# Altium schematic sheet ZNXC_V1.0.SchDoc
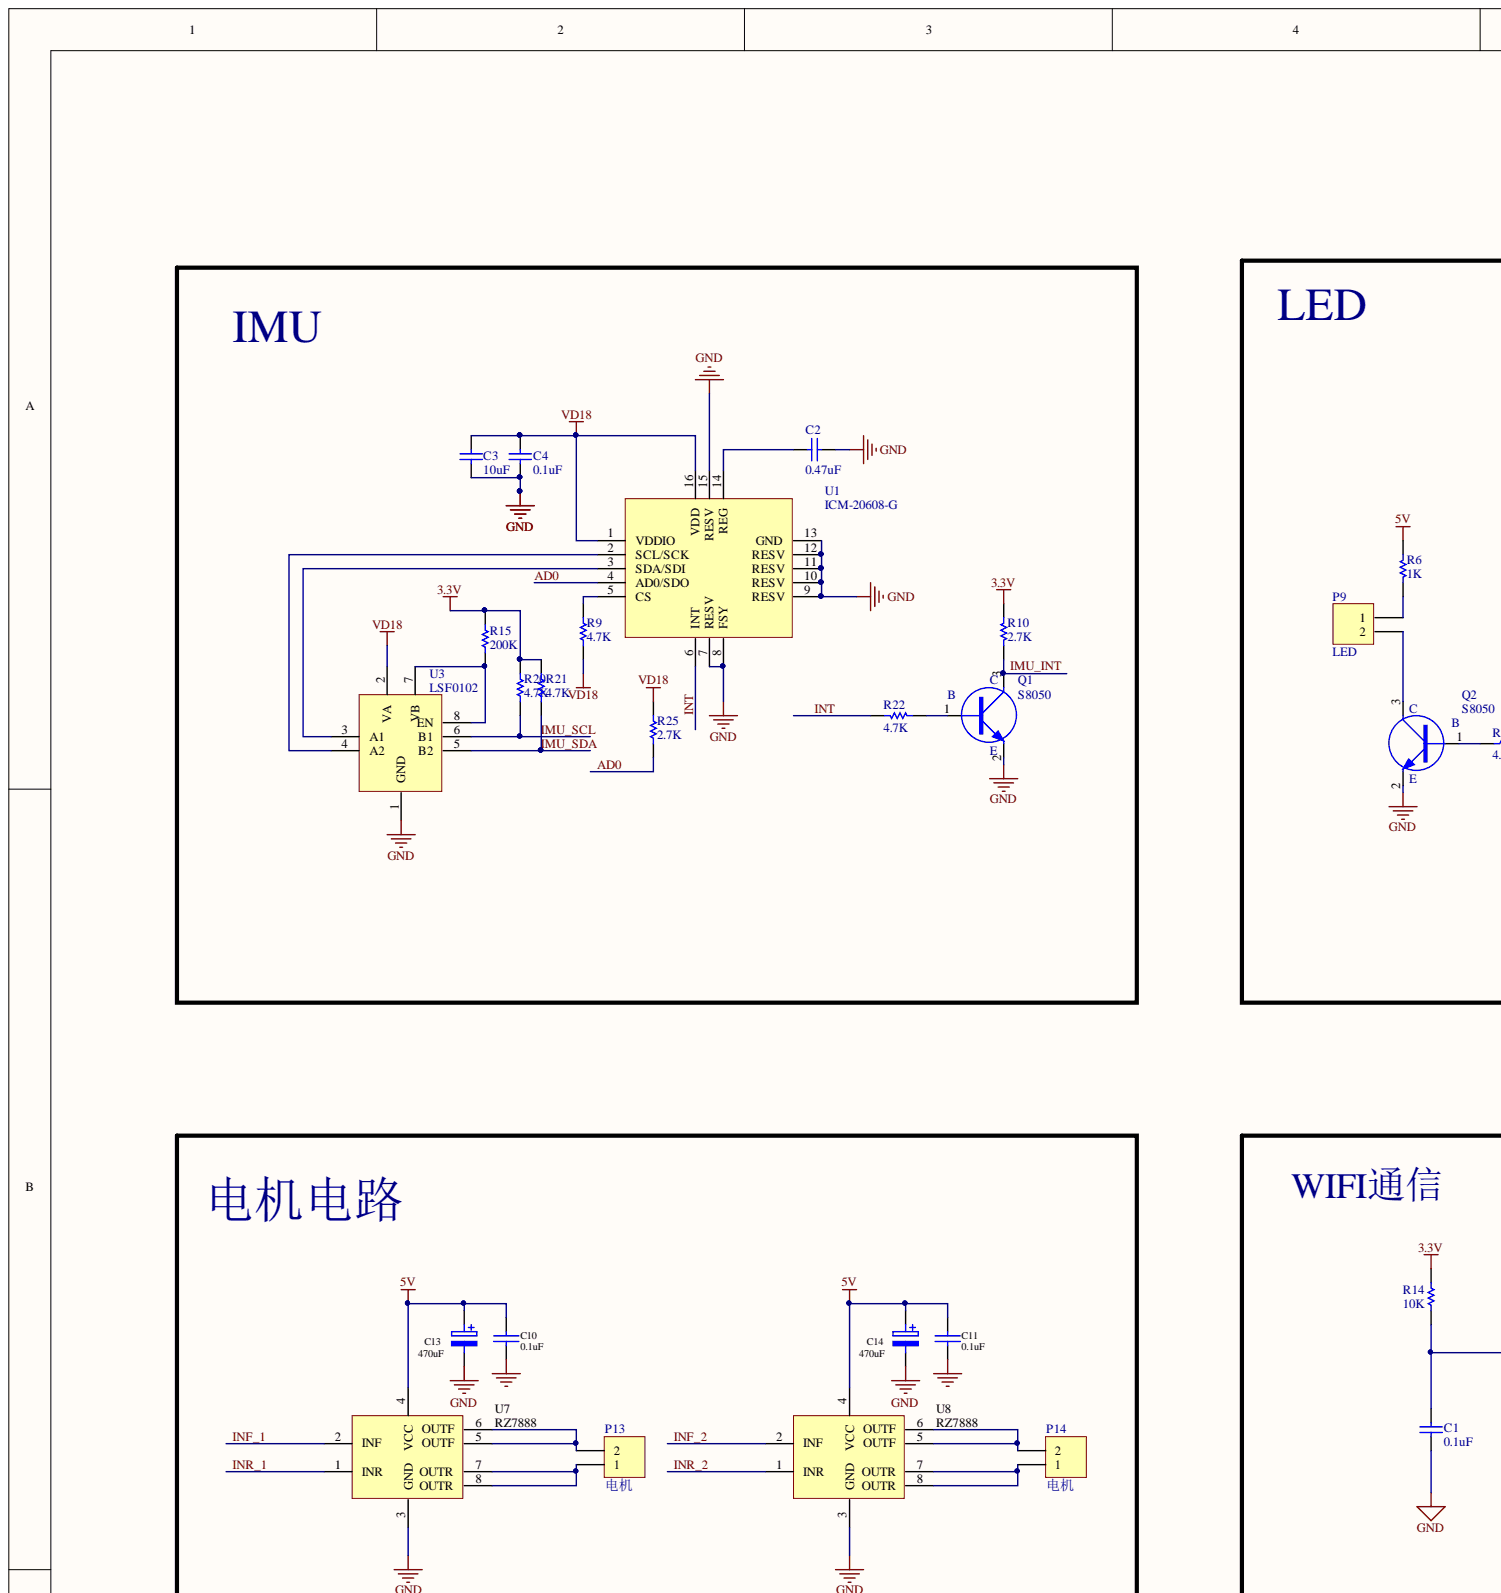
[diagram p0: ZNXC_V1.0.SchDoc, top left]
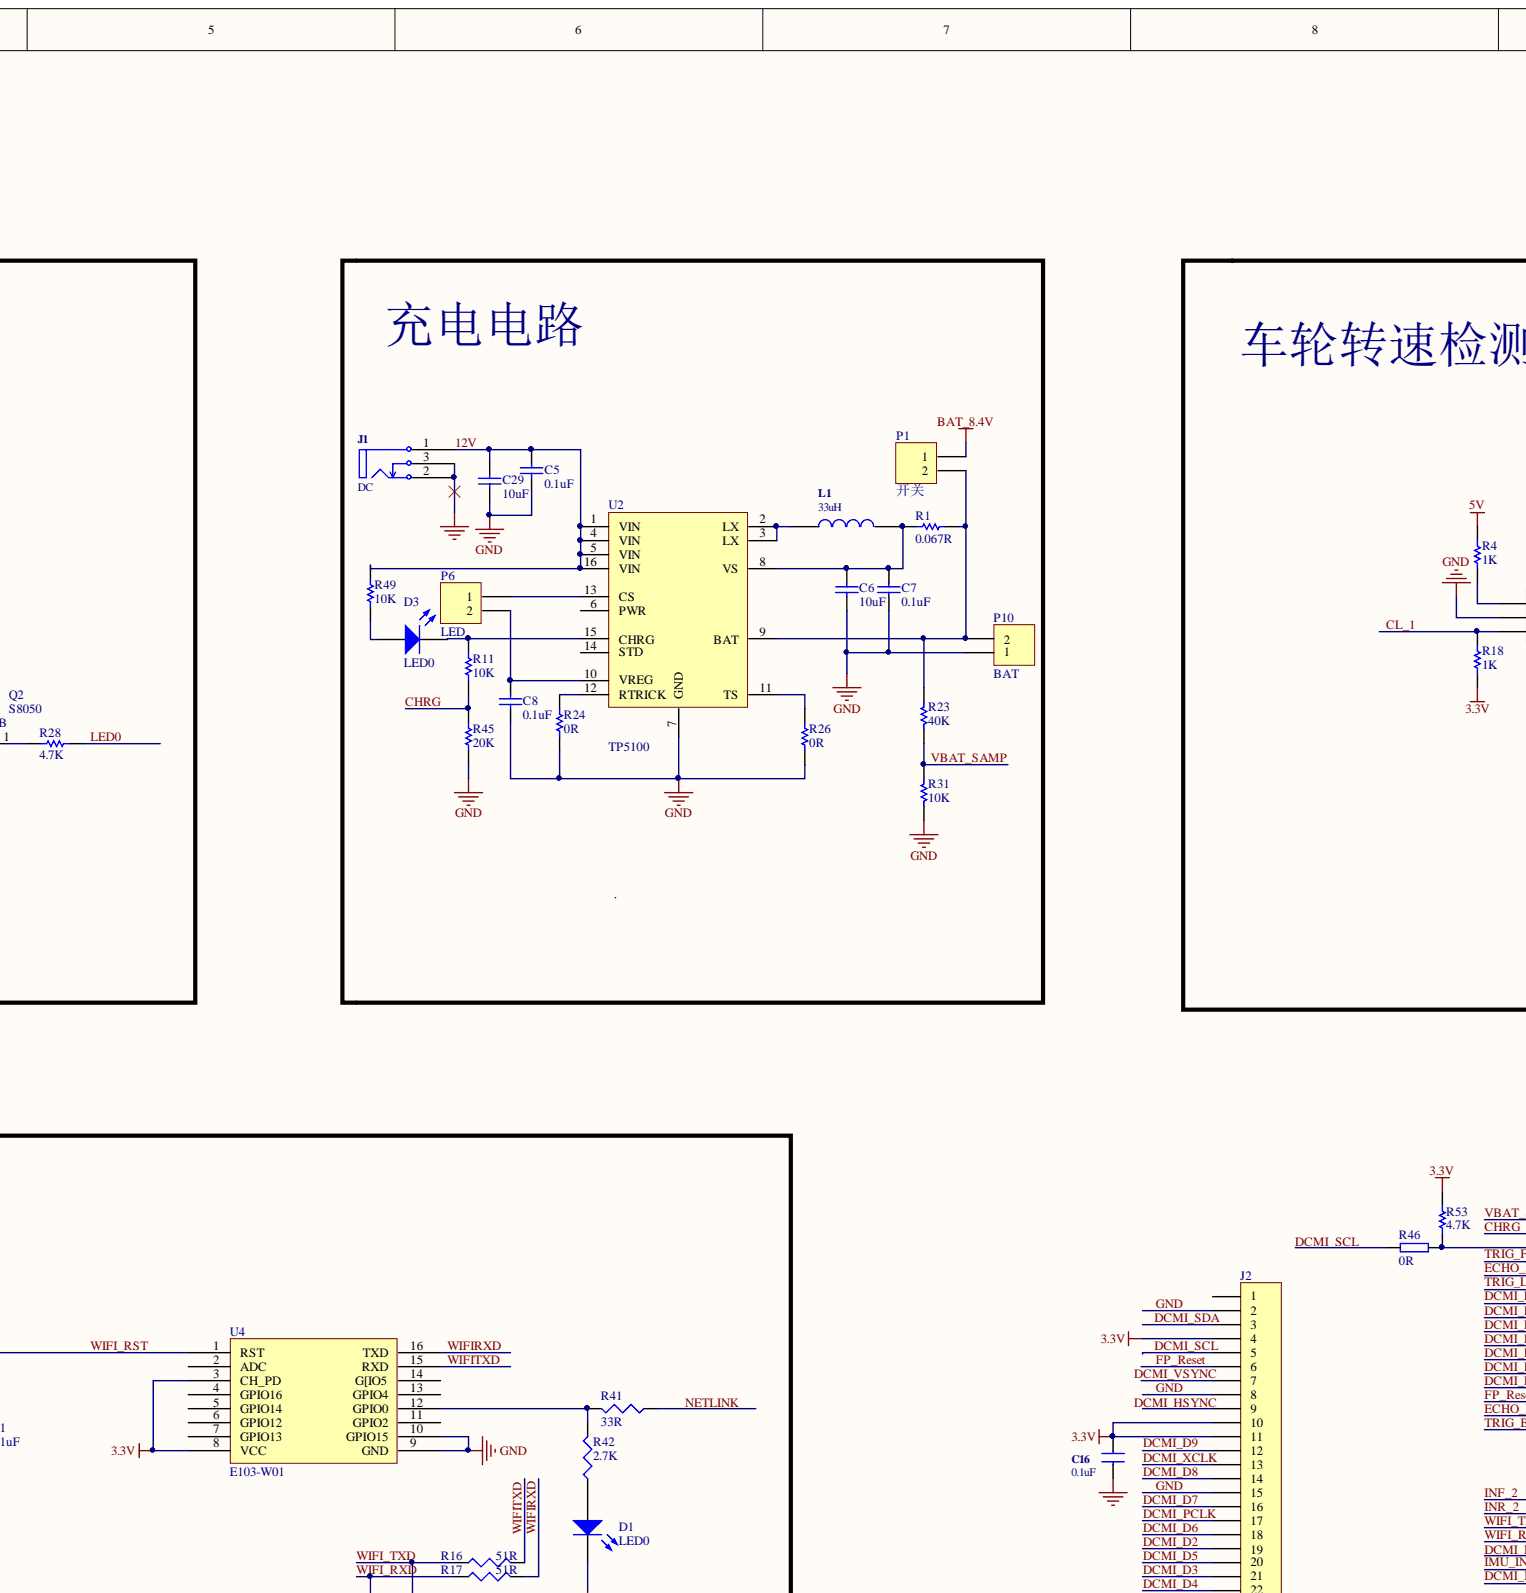
[diagram p1: ZNXC_V1.0.SchDoc, top centre]
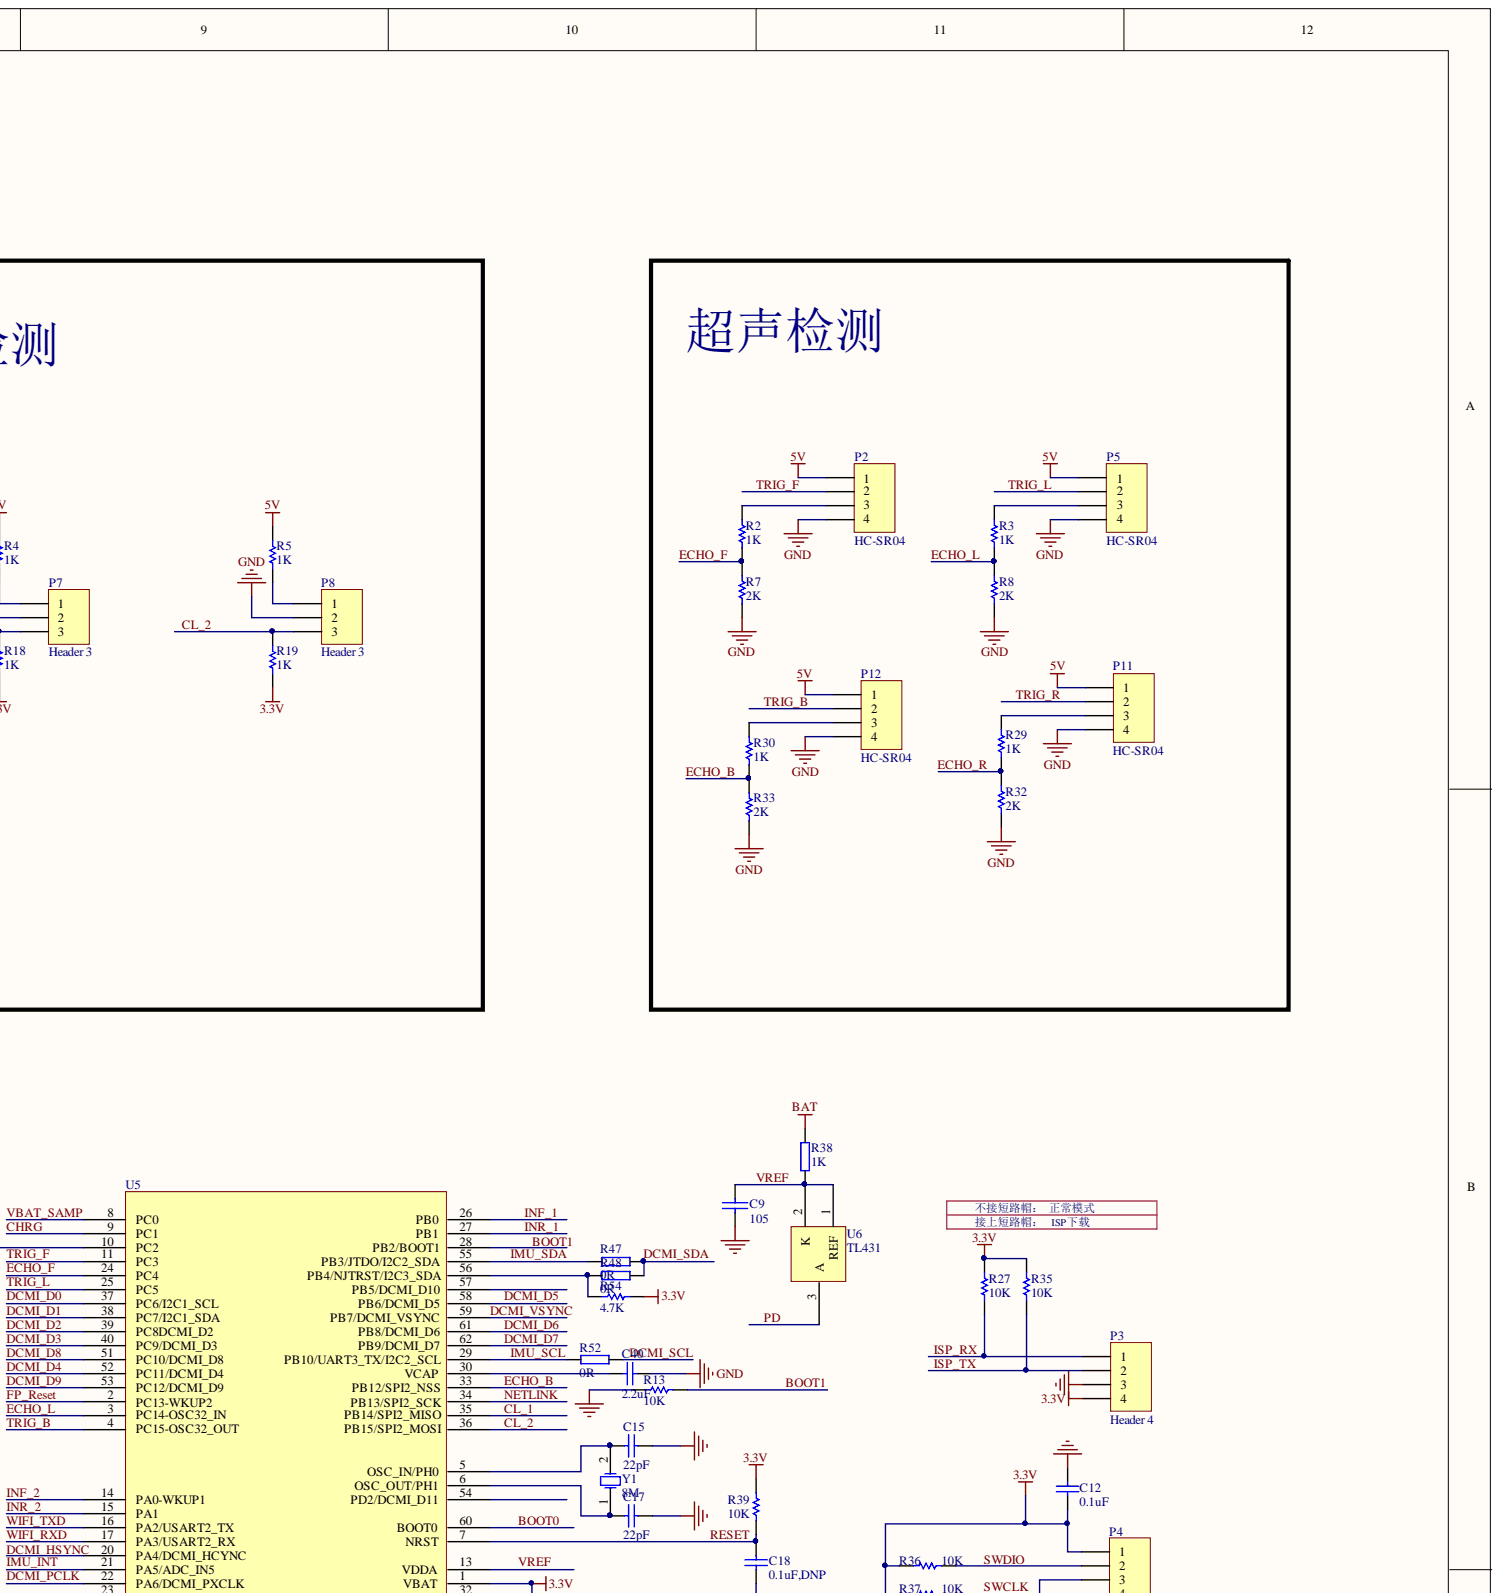
[diagram p2: ZNXC_V1.0.SchDoc, top right]
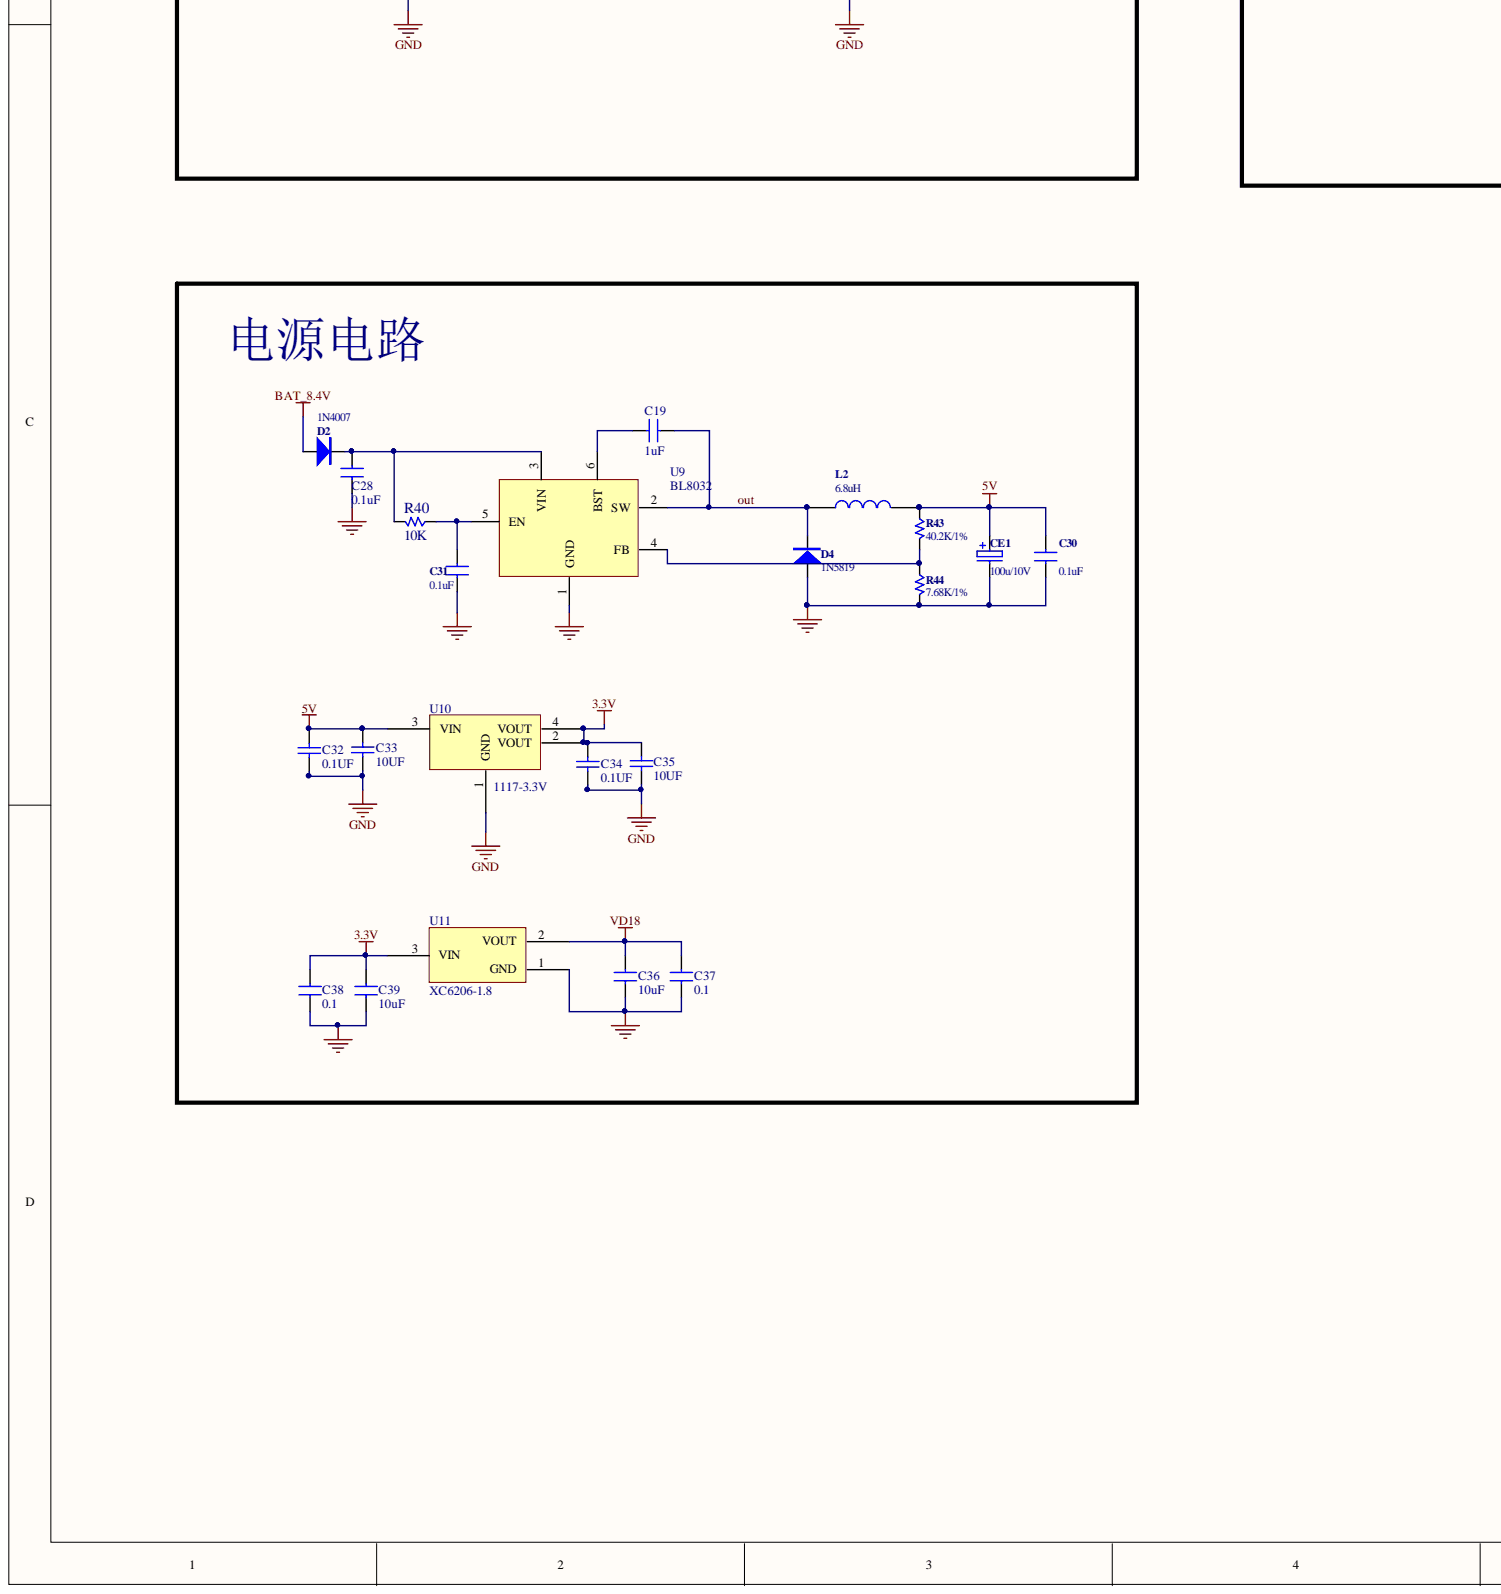
[diagram p3: ZNXC_V1.0.SchDoc, bottom left]
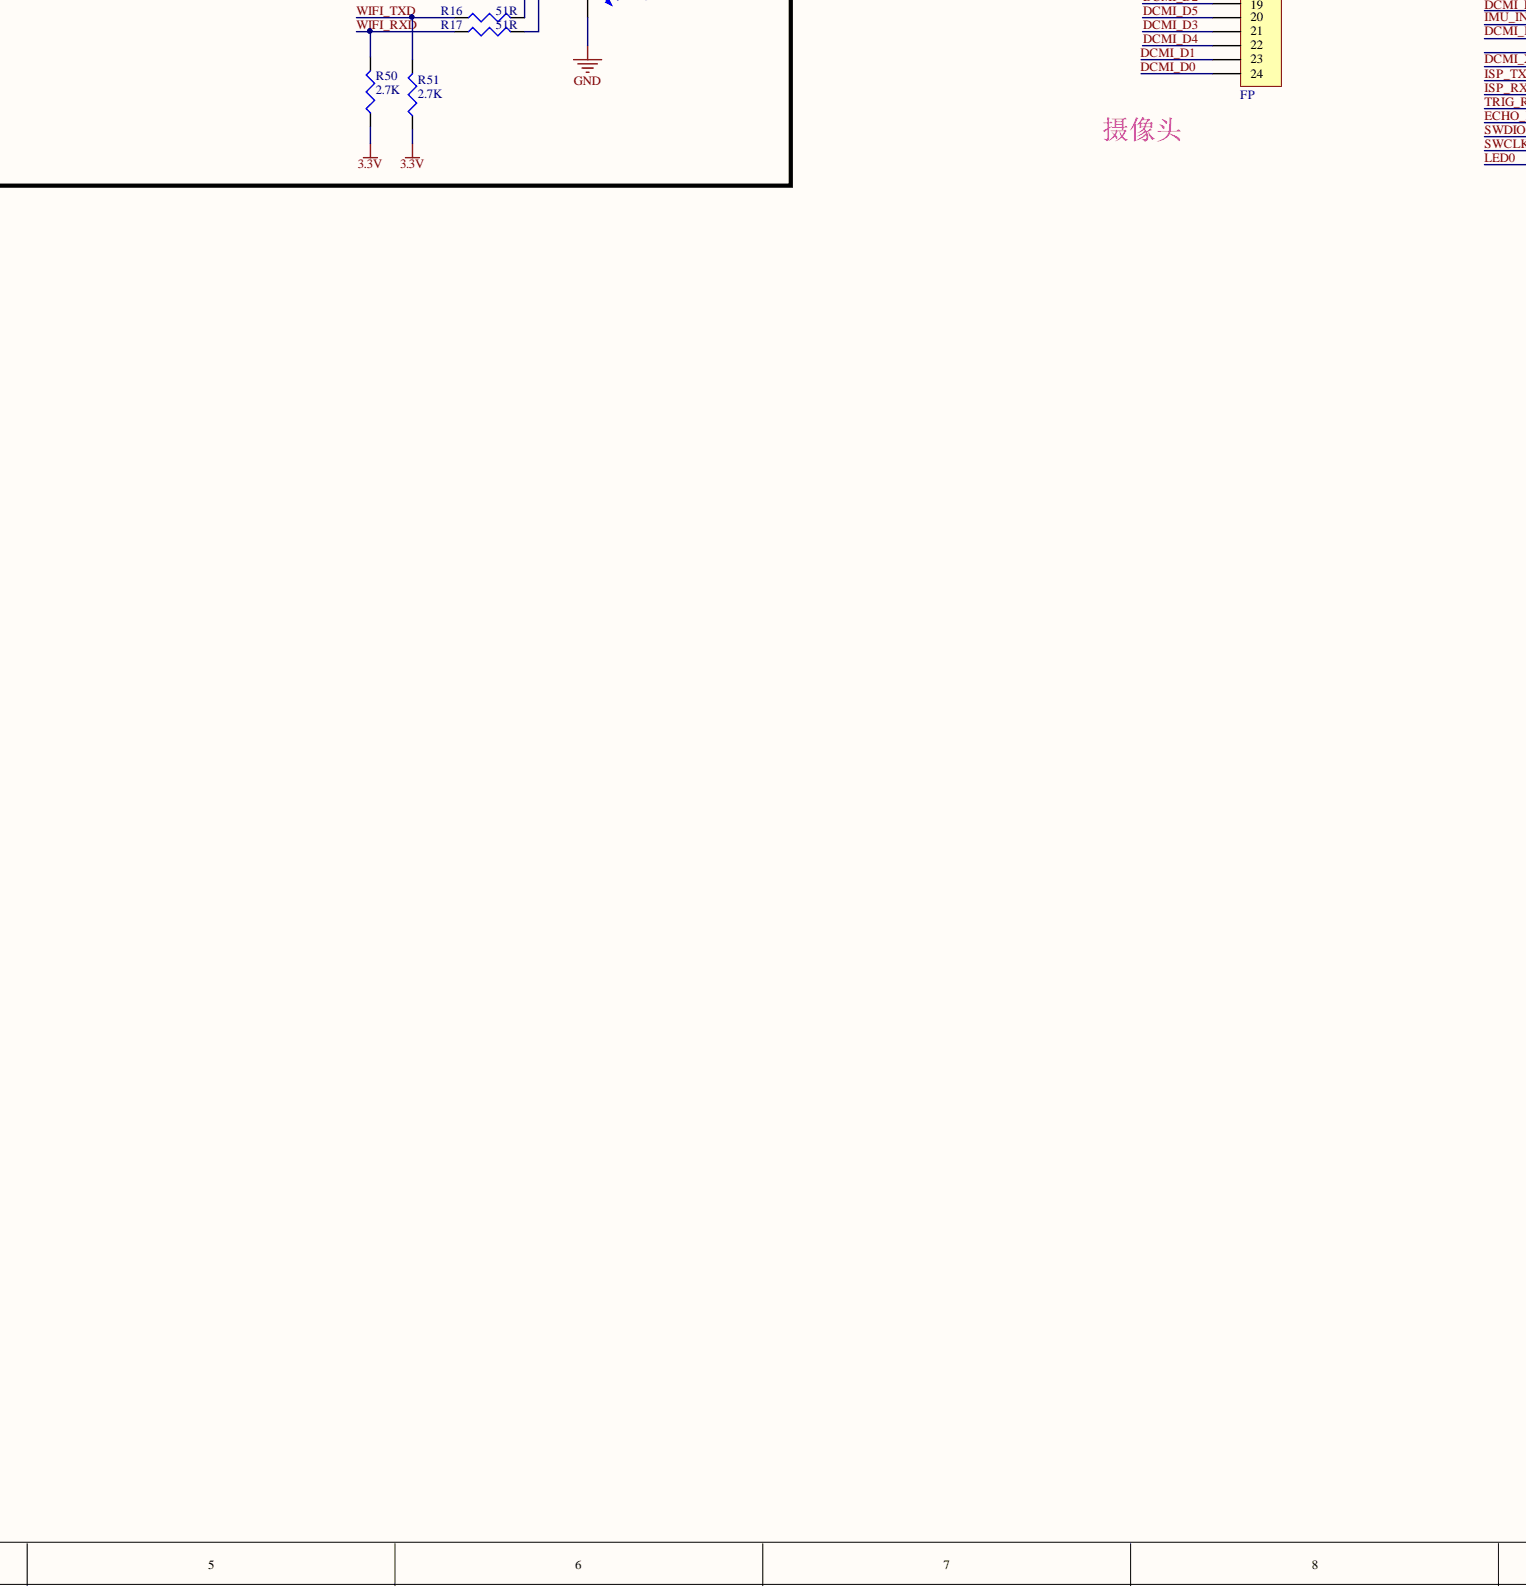
[diagram p4: ZNXC_V1.0.SchDoc, bottom centre]
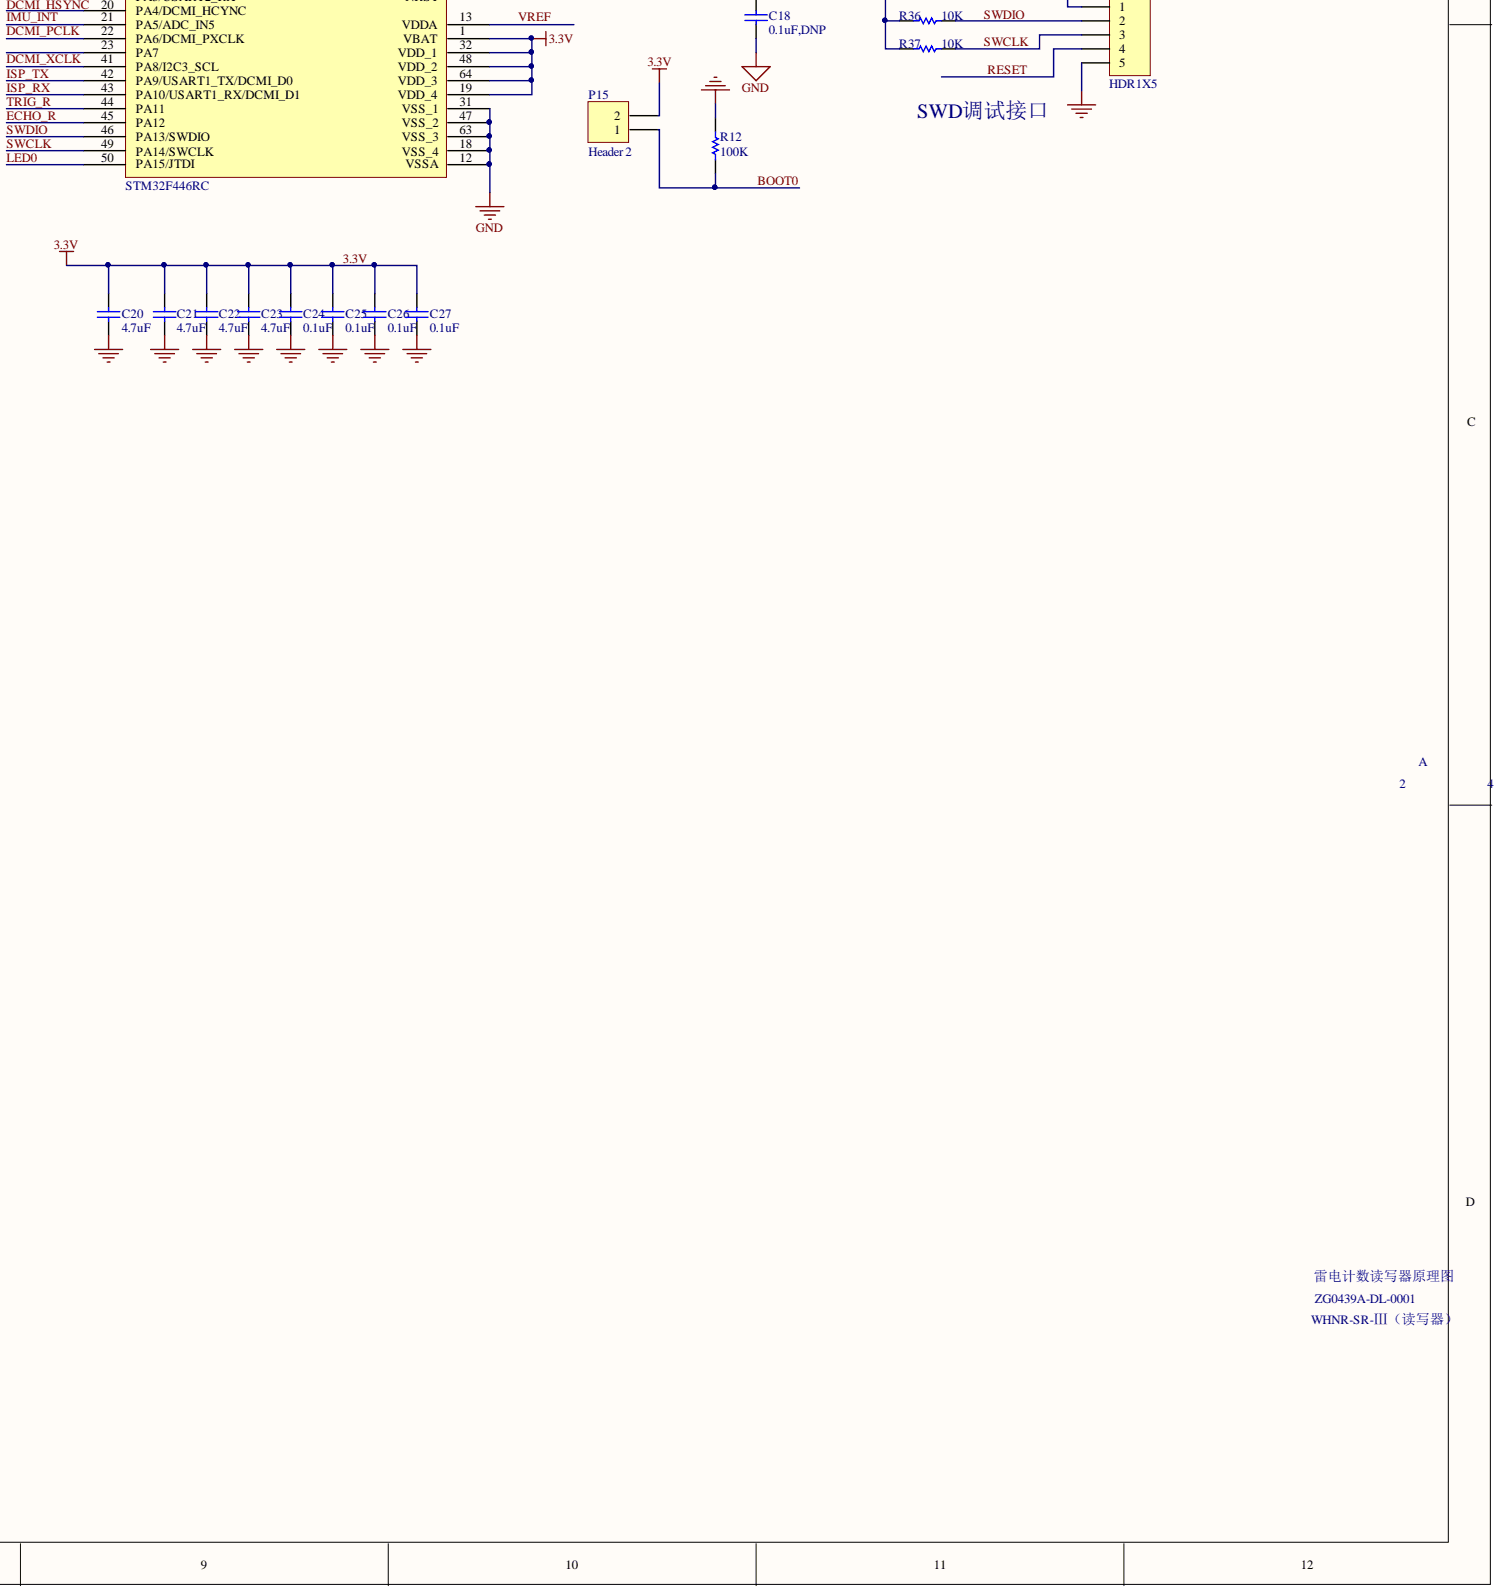
[diagram p5: ZNXC_V1.0.SchDoc, bottom right]

## Parts
C1  0.1uF  [0603C]
C2  0.47uF  [0603C]
C3  10uF  [0603C]
C4  0.1uF  [0603C]
C5  0.1uF  [0603C]
C6  10uF  [0603C]
C7  0.1uF  [0603C]
C8  0.1uF  [0603C]
C9  105  [SMD0603C]
C10  0.1uF  [0603C]
C11  0.1uF  [0603C]
C12  0.1uF  [0603C]
C13  470uF  [0603C]
C14  470uF  [0603C]
C15  22pF  [0603C]
C16  0.1uF  [C0603]
C17  22pF  [0603C]
C18  0.1uF,DNP  [0603C]
C19  1uF  [0603c]
C20  4.7uF  [0603C]
C21  4.7uF  [0603C]
C22  4.7uF  [0603C]
C23  4.7uF  [0603C]
C24  0.1uF  [0603C]
C25  0.1uF  [0603C]
C26  0.1uF  [0603C]
C27  0.1uF  [0603C]
C28  0.1uF  [C0603]
C29  10uF  [0603C]
C30  0.1uF  [C0603]
C31  0.1uF  [C0603]
C32  value 0.1UF  [0603C]  (hidden comment: Cap)
C33  value 10UF  [0603C]  (hidden comment: Cap)
C34  value 0.1UF  [0603C]  (hidden comment: Cap)
C35  value 10UF  [0603C]  (hidden comment: Cap)
C36  10uF  [C0603]
C37  0.1  [C0603]
C38  0.1  [C0603]
C39  10uF  [C0603]
C40  2.2uF  [0603C]
CE1  100u/10V  [CEO_C]
D1  LED0  [0603LED]
D2  1N4007  [DIODE/DO-214AC]
D3  LED0  [0603LED]
D4  1N5819  [DIODE/DO-214AC]
J1  DC  [dc5V]
J2  FP  [PFPC24/0.5]
L1  33uH  [IND/7575]
L2  6.8uH  [IND/7575]
P1  开关  [CON2]
P2  HC-SR04  [HDR1X4]
P3  Header 4  [HDR1X4]
P4  HDR1X5  [SIP4]
P5  HC-SR04  [HDR1X4]
P6  LED  [CON2]
P7  Header 3  [HDR1X3]
P8  Header 3  [HDR1X3]
P9  LED  [CON2]
P10  BAT  [CON2]
P11  HC-SR04  [HDR1X4]
P12  HC-SR04  [HDR1X4]
P13  电机  [CON2]
P14  电机  [CON2]
P15  Header 2  [HDR1X2]
Q1  S8050  [SOT-23]
Q2  S8050  [SOT-23]
R1  0.067R  [0603C]
R2  1K  [0603C]
R3  1K  [0603C]
R4  1K  [0603C]
R5  1K  [0603C]
R6  1K  [0603R]
R7  2K  value 1K  [0603C]
R8  2K  value 1K  [0603C]
R9  4.7K  [0603R]
R10  2.7K  [0603R]
R11  10K  [0603C]
R12  100K  [0603R]
R13  10K  [0603R]
R14  10K  [0603R]
R15  200K  [0603R]
R16  51R  [0603R]
R17  51R  [0603R]
R18  1K  [0603C]
R19  1K  [0603C]
R20  4.7K  [0603R]
R21  4.7K  [0603R]
R22  4.7K  [0603R]
R23  40K  [0603R]
R24  0R  [0603C]
R25  2.7K  [0603R]
R26  0R  [0603C]
R27  10K  [0603R]
R28  4.7K  [0603R]
R29  1K  [0603C]
R30  1K  [0603C]
R31  10K  [0603R]
R32  2K  [0603C]
R33  2K  value 1K  [0603C]
R35  10K  [0603R]
R36  10K  [0603R]
R37  10K  [0603R]
R38  1K  [SMD0603R]
R39  10K  [0603R]
R40  10K  [R0603]
R41  33R  [0603R]
R42  2.7K  [0603R]
R43  40.2K/1%  [R0603]
R44  7.68K/1%  [R0603]
R45  20K  [0603C]
R46  0R  [SMD0603R]
R47  0R  [SMD0603R]
R48  0R  [SMD0603R]
R49  10K  [0603C]
R50  2.7K  [0603R]
R51  2.7K  [0603R]
R52  0R  [SMD0603R]
R53  4.7K  [0603R]
R54  4.7K  [0603R]
U1  ICM-20608-G  [0603C]
U2  TP5100  [TP4057]
U3  LSF0102  [0603c]
U4  E103-W01  [E103-W01]
U5  STM32F446RC  [LQFP64_10X10P]
U6  TL431  [SOT23_N]
U7  RZ7888  [0603C]
U8  RZ7888  [0603C]
U9  BL8032  [SOT23-6]
U10  1117-3.3V  [SOT223]
U11  XC6206-1.8  [SOT23C]
Y1  8M  [XTAL1]

## Nets
5V: C10.1 C11.1 C13.1 C14.1 C30.1 C32.1 C33.1 CE1.1 L2.2 P2.1 P5.1 P11.1 P12.1 R4.2 R5.2 R6.1 R43.1 U7.4(VCC) U8.4(VCC) U10.3(VIN)
BAT: R38.1
BAT_8.4V: D2.A P1.1
VD18: C3.2 C4.2 C36.1 C37.1 R9.2 R25.1 U1.1(VDDIO) U1.16(VDD) U3.2(VA) U11.2
3.3V: C12.1 C16.2 C20.2 C21.2 C22.2 C23.2 C24.2 C25.2 C26.2 C27.2 C34.1 C35.1 C38.1 C39.1 J2.4 J2.10 J2.11 P3.4 P4.1 P15.2 R10.1 R14.2 R15.2 R18.1 R19.1 R20.2 R21.2 R27.2 R35.2 R36.1 R37.1 R39.2 R50.1 R51.1 R53.2 R54.2 U4.3(CH_PD) U4.8(VCC) U5.1(VBAT) U5.19(VDD_4) U5.32(VDD_1) U5.48(VDD_2) U5.64(VDD_3) U10.2(VOUT) U10.4(VOUT) U11.3
12V: C5.2 C29.1 J1.1 R49.2 U2.1(VIN) U2.4(VIN) U2.5(VIN) U2.16(VIN)
AD0: R25.2 U1.4(AD0/SDO)
BOOT0: P15.1 R12.1 U5.60(BOOT0)
BOOT1: R13.2 U5.28(PB2/BOOT1)
CHRG: R11.1 R45.2 U5.9(PC1)
CL_1: P7.3 R18.2 U5.35(PB14/SPI2_MISO)
CL_2: P8.3 R19.2 U5.36(PB15/SPI2_MOSI)
DCMI_D0: J2.24 U5.37(PC6/I2C1_SCL)
DCMI_D1: J2.23 U5.38(PC7/I2C1_SDA)
DCMI_D2: J2.19 U5.39(PC8DCMI_D2)
DCMI_D3: J2.21 U5.40(PC9/DCMI_D3)
DCMI_D4: J2.22 U5.52(PC11/DCMI_D4)
DCMI_D5: J2.20 U5.58(PB6/DCMI_D5)
DCMI_D6: J2.18 U5.61(PB8/DCMI_D6)
DCMI_D7: J2.16 U5.62(PB9/DCMI_D7)
DCMI_D8: J2.14 U5.51(PC10/DCMI_D8)
DCMI_D9: J2.12 U5.53(PC12/DCMI_D9)
DCMI_HSYNC: U5.20(PA4/DCMI_HCYNC)
DCMI_PCLK: J2.17 U5.22(PA6/DCMI_PXCLK)
DCMI_SCL: J2.5 R46.1 R52.2
DCMI_SDA: J2.3 R47.2 R48.2
DCMI_VSYNC: U5.59(PB7/DCMI_VSYNC)
DCMI_XCLK: J2.13 U5.41(PA8/I2C3_SCL)
ECHO_B: R30.1 R33.2 U5.33(PB12/SPI2_NSS)
ECHO_F: R2.1 R7.2 U5.24(PC4)
ECHO_L: R3.1 R8.2 U5.3(PC14-OSC32_IN)
ECHO_R: R29.1 R32.2 U5.45(PA12)
FP_RESET: J2.6 U5.2(PC13-WKUP2)
GND: C1.1 C2.1 C3.1 C4.1 C5.1 C6.1 C7.1 C8.1 C9.1 C10.2 C11.2 C12.2 C13.2 C14.2 C15.1 C16.1 C17.1 C18.1 C20.1 C21.1 C22.1 C23.1 C24.1 C25.1 C26.1 C27.1 C28.2 C29.2 C30.2 C31.2 C32.2 C33.2 C34.2 C35.2 C36.2 C37.2 C38.2 C39.2 C40.1 CE1.2 D1.2 D4.A J1.2 J1.3 J2.2 J2.8 J2.15 P2.4 P3.3 P4.5 P5.4 P7.2 P8.2 P10.1 P11.4 P12.4 Q1.2 Q2.2 R7.1 R8.1 R12.2 R13.1 R24.1 R26.1 R31.2 R32.1 R33.1 R44.2 R45.1 U1.7(RESV) U1.8(FSY) U1.9(RESV) U1.10(RESV) U1.11(RESV) U1.12(RESV) U1.13(GND) U1.15(RESV) U2.7(GND) U3.1(GND) U4.9(GND) U4.10(GPIO15) U5.12(VSSA) U5.18(VSS_4) U5.31(VSS_1) U5.47(VSS_2) U5.63(VSS_3) U7.3(GND) U8.3(GND) U9.1 U10.1(GND) U11.1
IMU_INT: Q1.3 R10.2 U5.21(PA5/ADC_IN5)
IMU_SCL: R20.1 R21.1 R47.1 R52.1 U3.5(B2) U3.6(B1) U5.29(PB10/UART3_TX/I2C2_SCL) U5.55(PB3/JTDO/I2C2_SDA)
INF_1: U5.26(PB0) U7.2(INF)
INF_2: U5.14(PA0-WKUP1) U8.2(INF)
INR_1: U5.27(PB1) U7.1(INR)
INR_2: U5.15(PA1) U8.1(INR)
INT: R22.1 U1.6(INT)
ISP_RX: P3.1 R27.1 U5.43(PA10/USART1_RX/DCMI_D1)
ISP_TX: P3.2 R35.1 U5.42(PA9/USART1_TX/DCMI_D0)
LED0: R28.1 U5.50(PA15/JTDI)
NETLINK: R41.1 U5.34(PB13/SPI2_SCK)
OUT: C19.2 D4.K L2.1 U9.2(SW)
PD: U6.3
RESET: C18.2 P4.4 R39.1 U5.7(NRST)
SWCLK: P4.3 R37.2 U5.49(PA14/SWCLK)
SWDIO: P4.2 R36.2 U5.46(PA13/SWDIO)
TRIG_B: P12.2 U5.4(PC15-OSC32_OUT)
TRIG_F: P2.2 U5.11(PC3)
TRIG_L: P5.2 U5.25(PC5)
TRIG_R: P11.2 U5.44(PA11)
VBAT_SAMP: R23.2 R31.1 U5.8(PC0)
VREF: C9.2 R38.2 U5.13(VDDA) U6.1 U6.2
WIFI_RST: C1.2 R14.1 U4.1(RST)
WIFI_RXD: R17.1 R50.2 U5.17(PA3/USART2_RX)
WIFI_TXD: R16.1 R51.2 U5.16(PA2/USART2_TX)
WIFIRXD: R17.2 U4.16(TXD)
WIFITXD: R16.2 U4.15(RXD)
NetC2_2: C2.2 U1.14(REG)
NetC6_2: C6.2 C7.2 L1.2 R1.2 U2.8(VS)
NetC8_2: C8.2 P6.2 U2.10(VREG)
NetC15_2: C15.2 U5.5(OSC_IN/PH0) Y1.2
NetC17_2: C17.2 U5.6(OSC_OUT/PH1) Y1.1
NetC19_1: C19.1 U9.6(BST)
NetC28_1: C28.1 D2.K R40.2 U9.3(VIN)
NetC31_1: C31.1 R40.1 U9.5(EN)
NetC40_2: C40.2 U5.30(VCAP)
NetD1_1: D1.1 R42.1
NetD3_1: D3.1 R49.1
NetD3_2: D3.2 R11.2 U2.15(CHRG)
NetL1_1: L1.1 U2.2(LX) U2.3(LX)
NetP1_2: P1.2 P10.2 R1.1 R23.1 U2.9(BAT)
NetP2_3: P2.3 R2.2
NetP5_3: P5.3 R3.2
NetP6_1: P6.1 U2.13(CS)
NetP7_1: P7.1 R4.1
NetP8_1: P8.1 R5.1
NetP9_1: P9.1 R6.2
NetP9_2: P9.2 Q2.3
NetP11_3: P11.3 R29.2
NetP12_3: P12.3 R30.2
NetP13_1: P13.1 U7.7(OUTR) U7.8(OUTR)
NetP13_2: P13.2 U7.5(OUTF) U7.6(OUTF)
NetP14_1: P14.1 U8.7(OUTR) U8.8(OUTR)
NetP14_2: P14.2 U8.5(OUTF) U8.6(OUTF)
NetQ1_1: Q1.1 R22.2
NetQ2_1: Q2.1 R28.2
NetR9_1: R9.1 U1.5(CS)
NetR15_1: R15.1 U3.7(VB) U3.8(EN)
NetR24_2: R24.2 U2.12(RTRICK)
NetR26_2: R26.2 U2.11(TS)
NetR41_2: R41.2 R42.2 U4.12(GPIO0)
NetR43_2: R43.2 R44.1 U9.4(FB)
NetR46_2: R46.2 R53.1 U5.10(PC2)
NetR48_1: R48.1 R54.1 U5.56(PB4/NJTRST/I2C3_SDA)
NetU1_2: U1.2(SCL/SCK) U3.4(A2)
NetU1_3: U1.3(SDA/SDI) U3.3(A1)
N00044:

Not connected: J2 1 7 9; U2 6(PWR) 14(STD); U4 2(ADC) 4(GPIO16) 5(GPIO14) 6(GPIO12) 7(GPIO13) 11(GPIO2) 13(GPIO4) 14(G[IO5); U5 23(PA7) 54(PD2/DCMI_D11) 57(PB5/DCMI_D10)

## Library data of the parts
C1-C8 C12 C15 C17 C18 C40 = CAP: Capacitor; Value: 100pF; Component Kind: Standard; Library Name: STM32.SCHLIB; Published: 8-Jun-2000; Revision: July-2002: Re-released for DXP Platform.; Publisher: Altium Limited
C9-C11 C16 C19 C28 C30 C31 = CAP: Capacitor; Component Kind: Standard
C13 C14 = ELECTRO2: Component Kind: Standard
C20-C27 C32-C35 = Cap: Capacitor; Component Kind: Standard; LatestRevisionDate: 17-Jul-2002; LatestRevisionNote: Re-released for DXP Platform.; Library Name: Miscellaneous Devices.IntLib; PackageReference: RAD-0.3; Published: 8-Jun-2000; Signal Integrity: Cap; Simulation: CAP; Publisher: Altium Limited
  C32-C35: PackageDescription: Radial Cap, Thru-Hole; 2 Leads; 0.3 in Pin Spacing
C29 = Cap: Capacitor; Value: 4.7uF; Component Kind: Standard; LatestRevisionDate: 17-Jul-2002; LatestRevisionNote: Re-released for DXP Platform.; Library Name: Miscellaneous Devices.IntLib; PackageReference: RAD-0.3; Published: 8-Jun-2000; Signal Integrity: Cap; Simulation: CAP; Publisher: Altium Limited
C36-C39 = C: Capacitor; Component Kind: Standard; Library Name: PEFIS.SchLib
CE1 = CE: Component Kind: Standard
D1 D3 = LED0: Typical INFRARED GaAs LED; Component Kind: Standard; LatestRevisionDate: 08-Jul-2005; LatestRevisionNote: Mech Layer 1 removed.; Library Name: Miscellaneous Devices.IntLib; PackageDescription: LED; 2 Leads; PackageReference: LED-0; Published: 8-Jun-2000; Simulation: LED0; Publisher: Altium Limited
D2 D4 = D: Diode; Component Kind: Standard
J1 = POWERCON: Component Kind: Standard
J2 = Header 24: Header, 24-Pin; Component Kind: Standard; LatestRevisionDate: 17-Jul-2002; LatestRevisionNote: Re-released for DXP Platform.; Library Name: Miscellaneous Connectors.IntLib; Signal Integrity: Connector; Publisher: Altium Limited
L1 L2 = INDUCTOR: Component Kind: Standard
P1 P6 P9 P10 P13-P15 = Header 2: Header, 2-Pin; Component Kind: Standard; LatestRevisionDate: 17-Jul-2002; LatestRevisionNote: Re-released for DXP Platform.; Library Name: Miscellaneous Connectors.IntLib; Signal Integrity: Connector; Publisher: Altium Limited
P2 P3 P5 P11 P12 = Header 4: Header, 4-Pin; Component Kind: Standard; LatestRevisionDate: 17-Jul-2002; LatestRevisionNote: Re-released for DXP Platform.; Library Name: Miscellaneous Connectors.IntLib; Signal Integrity: Connector; Publisher: Altium Limited
P4 = Header 5: Header, 5-Pin; Component Kind: Standard; LatestRevisionDate: 17-Jul-2002; LatestRevisionNote: Re-released for DXP Platform.; Library Name: Miscellaneous Connectors.IntLib; Signal Integrity: Connector; Publisher: Altium Limited
P7 P8 = Header 3: Header, 3-Pin; Component Kind: Standard; LatestRevisionDate: 17-Jul-2002; LatestRevisionNote: Re-released for DXP Platform.; Library Name: Miscellaneous Connectors.IntLib; Signal Integrity: Connector; Publisher: Altium Limited
Q1 Q2 = NPN_1: Component Kind: Standard
R1 R11 R24 R26 R32 R36 R37 R45 R49 = Res1: Resistor; Value: 1K; Component Kind: Standard; LatestRevisionDate: 17-Jul-2002; LatestRevisionNote: Re-released for DXP Platform.; Library Name: Miscellaneous Devices.IntLib; PackageReference: AXIAL-0.3; Published: 8-Jun-2000; Signal Integrity: Res; Simulation: RESISTOR; Publisher: Altium Limited
R2-R5 R7 R8 R13 R18 R19 R27 R29 R30 R33 R35 = Res1: Resistor; Component Kind: Standard; LatestRevisionDate: 17-Jul-2002; LatestRevisionNote: Re-released for DXP Platform.; Library Name: Miscellaneous Devices.IntLib; PackageReference: AXIAL-0.3; Published: 8-Jun-2000; Signal Integrity: Res; Simulation: RESISTOR; Publisher: Altium Limited
  R2-R5 R18 R19 R29 R30: Value: 1K
R6 = res1: Resistor; Component Kind: Standard; Library Name: STM32.SCHLIB; Published: 8-Jun-2000; Revision: July-2002: Re-released for DXP Platform.; Signal Integrity: Res; Simulation: RESISTOR; Value: 1K; Publisher: Altium Limited
R9 R10 R12 R14 R15 R20-R23 R25 R28 R31 R39 R53 R54 = res1: Resistor; Value: 1K; Component Kind: Standard; Library Name: STM32.SCHLIB; Published: 8-Jun-2000; Revision: July-2002: Re-released for DXP Platform.; Signal Integrity: Res; Simulation: RESISTOR; Publisher: Altium Limited
R16 R17 R41 R42 R50 R51 = RES1: Component Kind: Standard
R38 R46-R48 R52 = RES: Component Kind: Standard
R40 R43 R44 = R: Resistor; Component Kind: Standard
  R43 R44: Library Name: CONNECTOR.SCHLIB
U1 = DS-000081: Capacitor; Component Kind: Standard; Library Name: ZNXC_V1.0.SCHLIB
U2 = TP5100: Capacitor; Value: 4.7uF; Component Kind: Standard; LatestRevisionDate: 17-Jul-2002; LatestRevisionNote: Re-released for DXP Platform.; PackageReference: RAD-0.3; Published: 8-Jun-2000; Signal Integrity: Cap; Simulation: CAP; Library Name: ZNXC_V1.0.SCHLIB; Publisher: Altium Limited
U3 = LSF0102: Polarized Capacitor (Radial); Value: 100pF; Component Kind: Standard; LatestRevisionDate: 17-Jul-2002; LatestRevisionNote: Re-released for DXP Platform.; PackageReference: RB7.6-15; Published: 8-Jun-2000; Signal Integrity: Cap Pol; Simulation: CAP; Library Name: ZNXC_V1.0.SCHLIB; Publisher: Altium Limited
U4 = E103-W01: Diode; Component Kind: Standard; Library Name: DCQ-01.SCHLIB
U6 = TL432: Component Kind: Standard
U7 U8 = RZ7888: Polarized Capacitor (Axial); Component Kind: Standard; Library Name: ZNXC_V1.0.SCHLIB
U9 = BL8032: Diode Bridge; Component Kind: Standard; Library Name: F718_XP_V1.0.SCHLIB
U10 = 1117: LDO; Component Kind: Standard; LatestRevisionDate: 17-Jul-2002; LatestRevisionNote: Re-released for DXP Platform.; PackageReference: POLAR0.8; Published: 8-Jun-2000; Signal Integrity: Cap Pol; Simulation: CAP; Library Name: TS-QBF-05.SCHLIB; Publisher: Altium Limited
U11 = XC6206: Component Kind: Standard; Library Name: PEFIS.SchLib
Y1 = XTAL: Crystal Oscillator; Y?: 32.768kHz; Component Kind: Standard; LatestRevisionDate: 17-Jul-2002; LatestRevisionNote: Re-released for DXP Platform.; Library Name: STM32.SCHLIB; PackageReference: R38; Published: 8-Jun-2000; Simulation: XTAL; Publisher: Altium Limited
U5 = STM32F446RC: Component Kind: Standard; Library Name: ZNXC_V1.0_1.SCHLIB
